# Altium schematic sheet Stm32.SchDoc
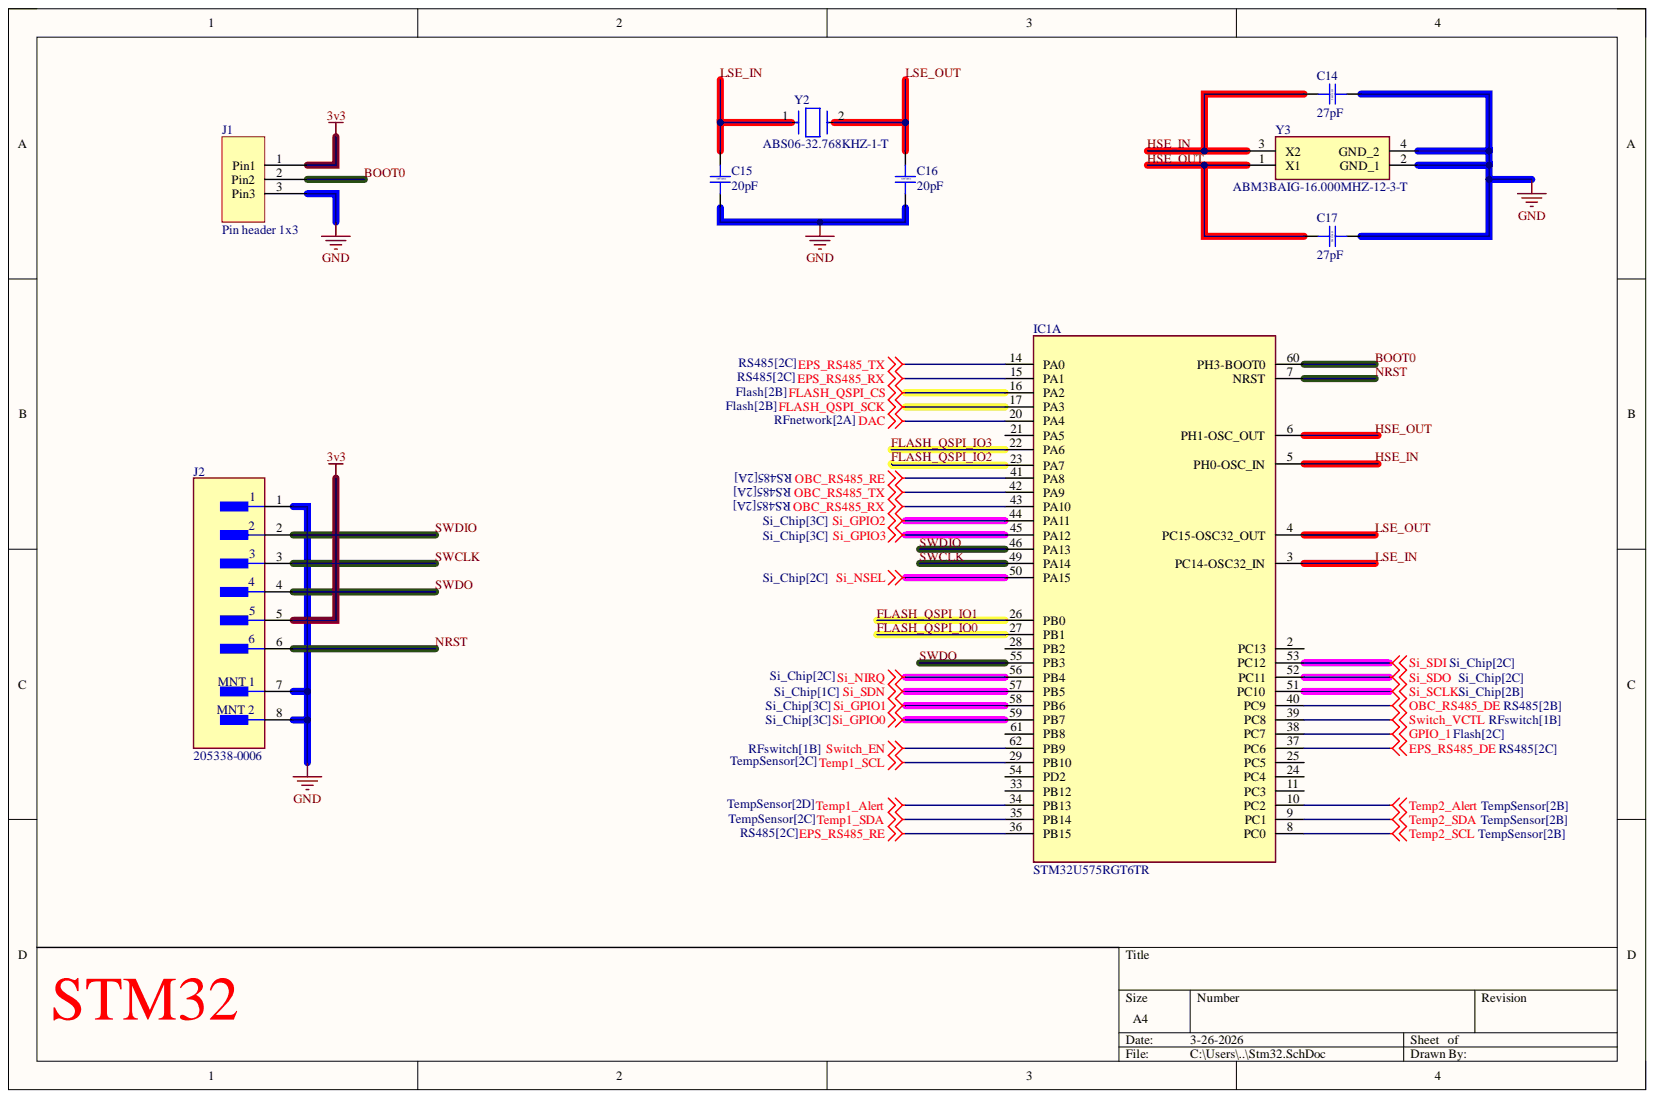
[diagram p0: Stm32.SchDoc]

## Parts
C14  27pF  [CAP_0603]
C15  20pF  [CAP_0603]
C16  20pF  [CAP_0603]
C17  27pF  [CAP_0603]
IC1  STM32U575RGT6TR  [QFP50P1200X1200X160-64N]  (part A)
J1  Pin header 1x3  [HEADER 3]
J2  205338-0006  [FP-2053380006-MFG]
Y2  ABS06-32.768KHZ-1-T  [FP-ABS06-MFG]
Y3  ABM3BAIG-16.000MHZ-12-3-T  [ABM3BAIG]

## Nets
3v3: J1.1 J2.5
DAC: IC1.20(PA4)
EPS_RS485_DE: IC1.37(PC6)
EPS_RS485_RE: IC1.36(PB15)
EPS_RS485_RX: IC1.15(PA1)
EPS_RS485_TX: IC1.14(PA0)
FLASH_QSPI_CS: IC1.16(PA2)
FLASH_QSPI_SCK: IC1.17(PA3)
GND: C14.2 C15.1 C16.1 C17.2 J1.3 J2.1 J2.7 J2.8 Y3.2(GND_1) Y3.4(GND_2)
GPIO_1: IC1.38(PC7)
OBC_RS485_DE: IC1.40(PC9)
OBC_RS485_RE: IC1.41(PA8)
OBC_RS485_RX: IC1.43(PA10)
OBC_RS485_TX: IC1.42(PA9)
Si_GPIO0: IC1.59(PB7)
Si_GPIO1: IC1.58(PB6)
Si_GPIO2: IC1.44(PA11)
Si_GPIO3: IC1.45(PA12)
Si_NIRQ: IC1.56(PB4)
Si_NSEL: IC1.50(PA15)
Si_SCLK: IC1.51(PC10)
Si_SDI: IC1.53(PC12)
Si_SDN: IC1.57(PB5)
Si_SDO: IC1.52(PC11)
Switch_EN: IC1.62(PB9)
Switch_VCTL: IC1.39(PC8)
Temp1_Alert: IC1.34(PB13)
Temp1_SCL: IC1.29(PB10)
Temp1_SDA: IC1.35(PB14)
Temp2_Alert: IC1.10(PC2)
Temp2_SCL: IC1.8(PC0)
Temp2_SDA: IC1.9(PC1)
BOOT0: IC1.60(PH3-BOOT0) J1.2
FLASH_QSPI_IO0: IC1.27(PB1)
FLASH_QSPI_IO1: IC1.26(PB0)
FLASH_QSPI_IO2: IC1.23(PA7)
FLASH_QSPI_IO3: IC1.22(PA6)
HSE_IN: C14.1 IC1.5(PH0-OSC_IN) Y3.3(X2)
HSE_OUT: C17.1 IC1.6(PH1-OSC_OUT) Y3.1(X1)
LSE_IN: C15.2 IC1.3(PC14-OSC32_IN) Y2.1
LSE_OUT: C16.2 IC1.4(PC15-OSC32_OUT) Y2.2
NRST: IC1.7(NRST) J2.6
SWCLK: IC1.49(PA14) J2.3
SWDIO: IC1.46(PA13) J2.2
SWDO: IC1.55(PB3) J2.4

Not connected: IC1 2(PC13) 11(PC3) 21(PA5) 24(PC4) 25(PC5) 28(PB2) 33(PB12) 54(PD2) 61(PB8)

## Library data of the parts
C14-C17 = Capacitor-SMD-0603: Capacitor SMD 0603 RATED; Component Kind: Standard; Library Name: STeRG-Passives-Standard.SchLib
IC1 = STM32U575RGT6TR: Integrated Circuit; Manufacturer_Name: STMicroelectronics; Arrow Part Number: STM32U575RGT6TR; Arrow Price/Stock: https://www.arrow.com/en/products/stm32u575rgt6tr/stmicroelectronics?utm_currency=USD&region=nac; Component Kind: Standard; Datasheet Link: https://www.st.com/resource/en/datasheet/stm32u575ag.pdf; Height: 1.6mm; Library Name: STeRG-ICs-Standard.SchLib; Manufacturer_Part_Number: STM32U575RGT6TR; Mouser Part Number: 511-STM32U575RGT6TR; Mouser Price/Stock: https://www.mouser.co.uk/ProductDetail/STMicroelectronics/STM32U575RGT6TR?qs=T%252BzbugeAwjjwoz%252BinJLUwQ%3D%3D
J2 = CMP-00257-108204701-1: 205338-0006 Connector Shrouded Header Positive Lock 6 Position 2mm Pitch Right Angle Surface Mount T/R; Manufacturer Lifecycle Status: ACTIVE; Gender: Male; Number of Circuits: 6; Component Kind: Standard; Contact Plating: Gold; Country of Origin: MY; Library Name: Altium Content Vault; Lifecycle Status: Production; NexarPartId: 108204701; Number of Rows: 1; Orientation: Right Angle; RoHS: Compliant; Schedule B: 8536694040
Y2 = CMP-27762-012387-2: CRYSTAL 32.7680KHZ 12.5PF SMD; ESR (Equivalent Series Resistance): 90kR; Frequency Tolerance: 10ppm; Case/Package: CSMD; Component Kind: Standard; Depth: 1.2mm; Frequency: 32.768kHz; Height: 0.6mm; Lead Free: Lead Free; Length: 2mm; Library Name: Altium Content Vault; Load Capacitance: 12.5pF; Max Operating Temperature: 85°C; Min Operating Temperature: -40°C; Mount: Surface Mount; Number of Pins: 2; Operating Frequency: 32.768 kHz; Package Quantity: 3000; Packaging: Tape and Reel; Radiation Hardening: No; REACH SVHC: No SVHC; RoHS Compliant: Yes; Series: ABS06; Series Resistance: 90kR; Termination: SMD/SMT; Tolerance: 10ppm; Width: 1.2mm
Y3 = ABM3BAIG-16.000MHZ-12-3-T: Crystal or Oscillator; Manufacturer_Name: ABRACON; Component Kind: Standard; Datasheet Link: https://abracon.com/AIGcrystals/ABM3BAIG.pdf; Height: 0.9mm; Library Name: SamacSys.SchLib; Manufacturer_Part_Number: ABM3BAIG-16.000MHZ-12-3-T
J1 = Pin header 1x3: Component Kind: Standard; Library Name: SamacSys.SchLib
